# Altium schematic sheet [6] - A2 Full Schematic.SchDoc
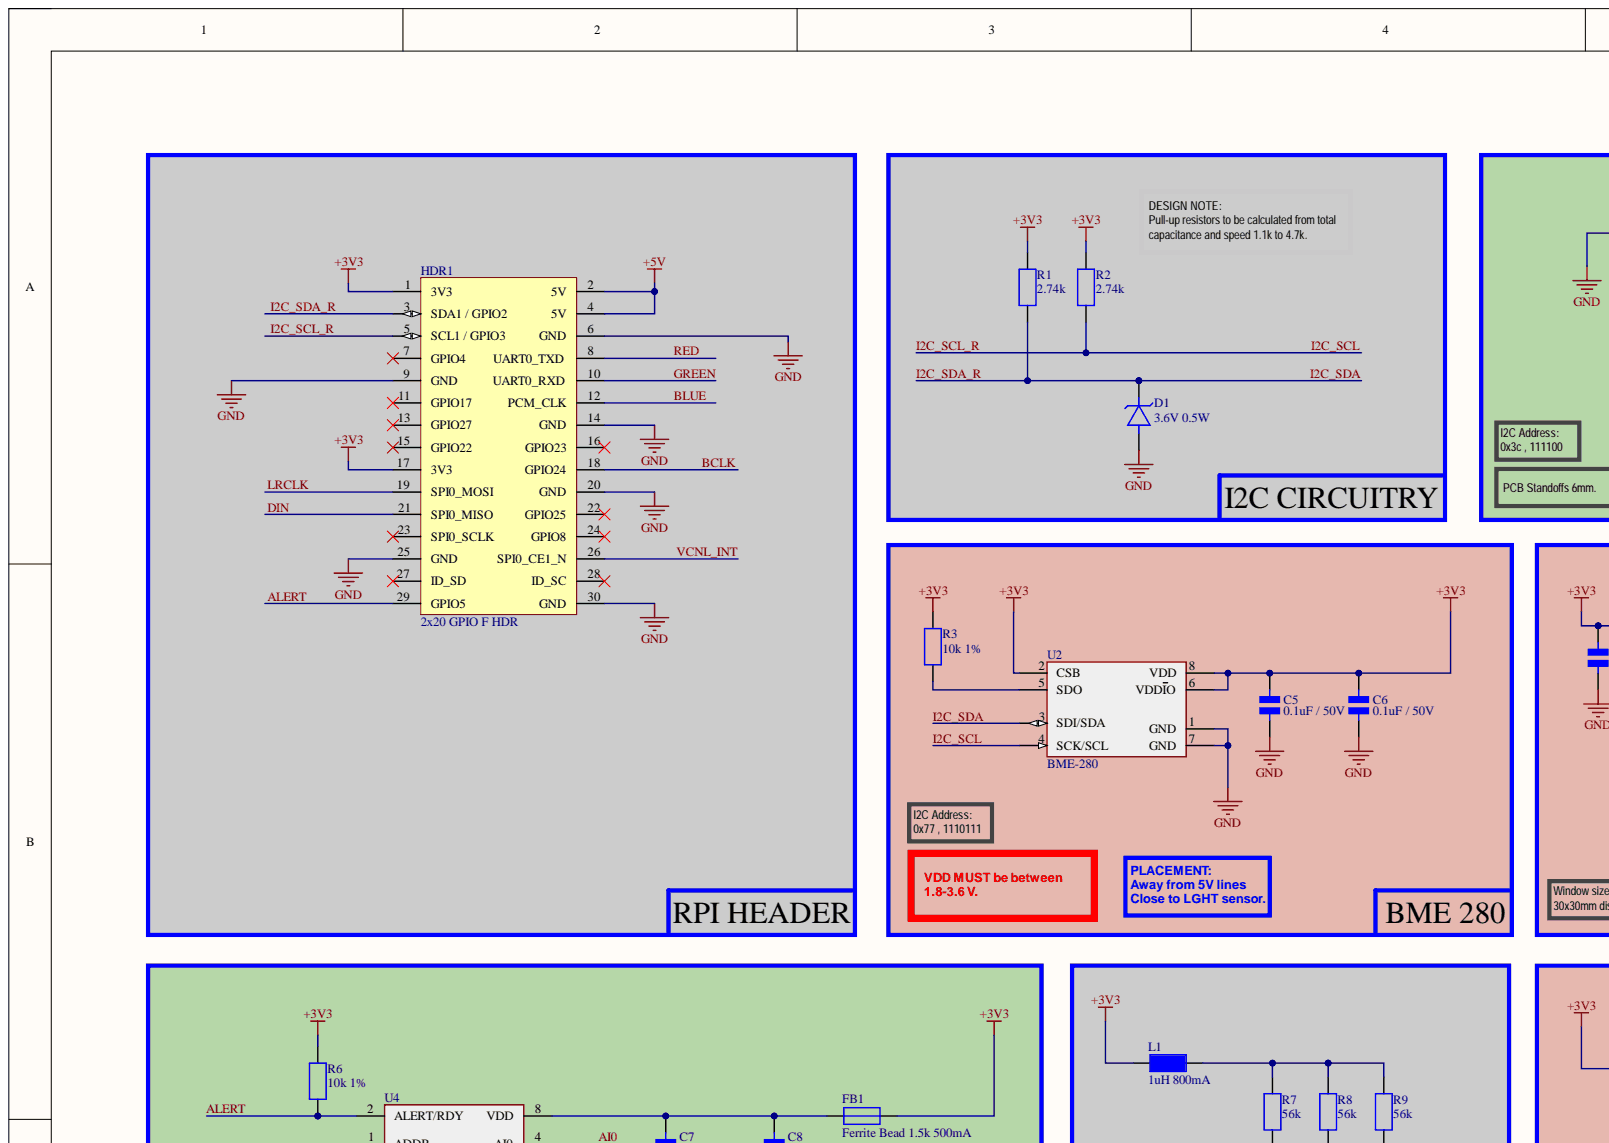
[diagram p0: [6] - A2 Full Schematic.SchDoc, top left]
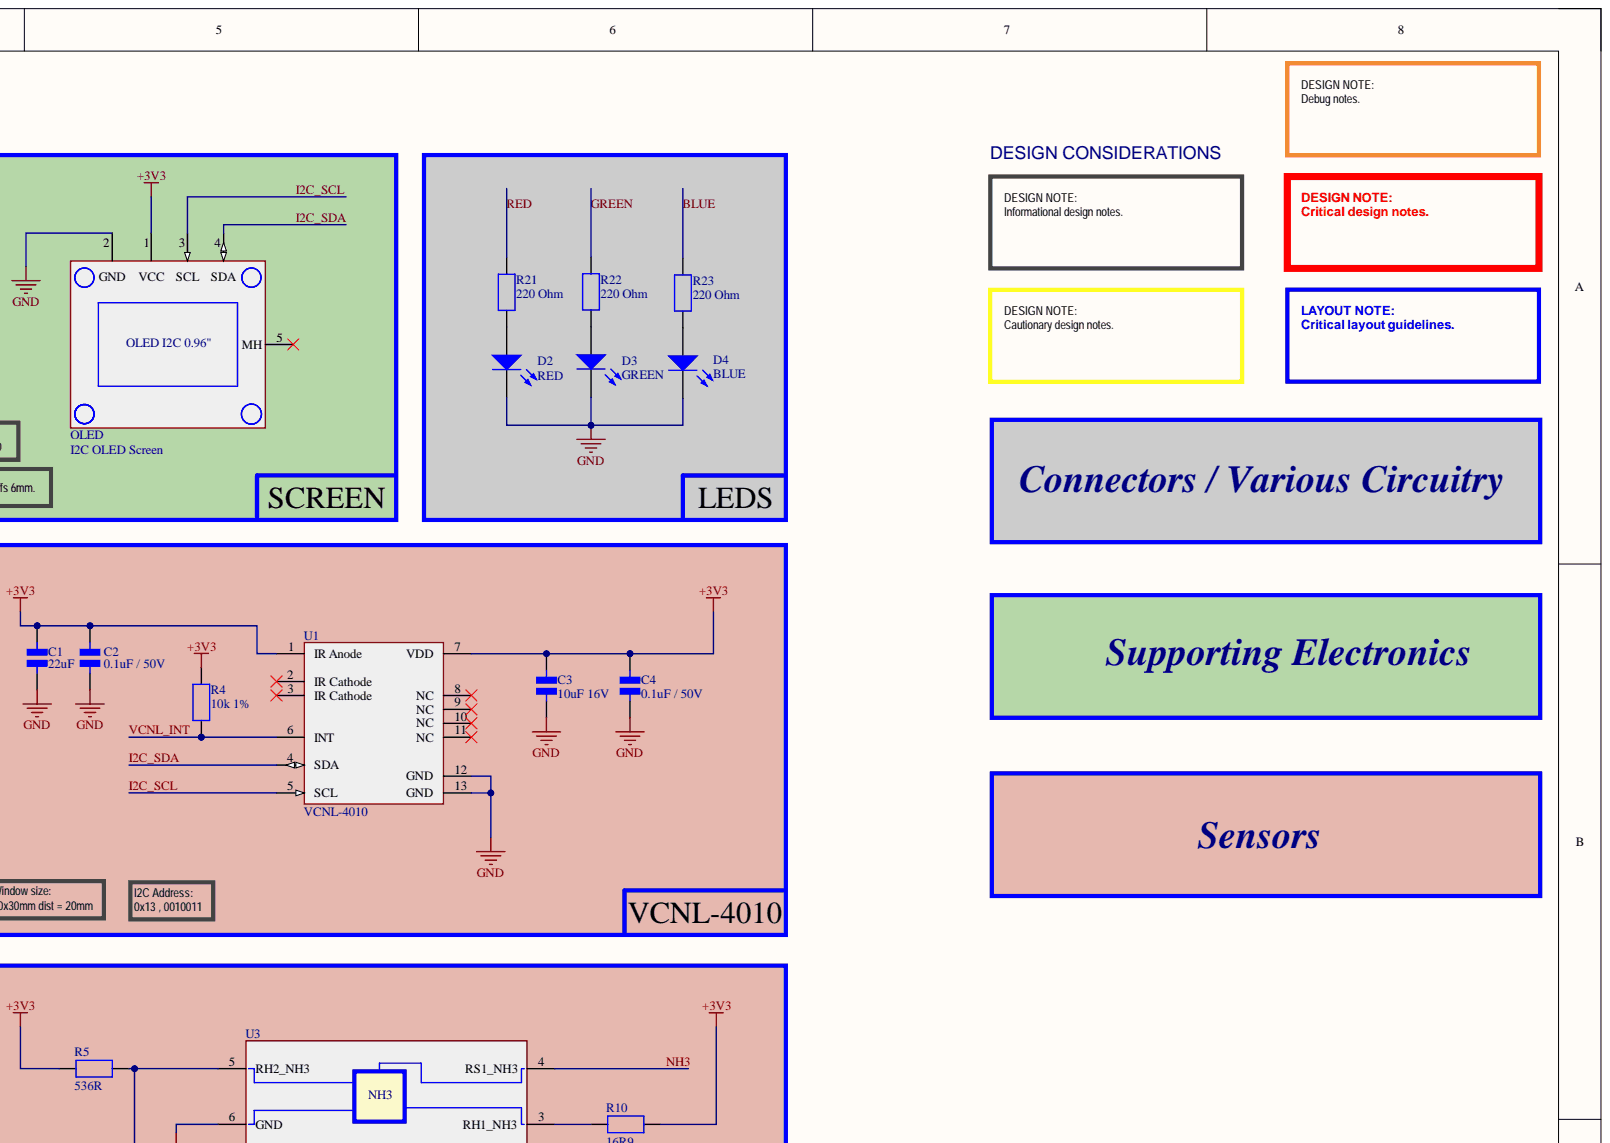
[diagram p1: [6] - A2 Full Schematic.SchDoc, top right]
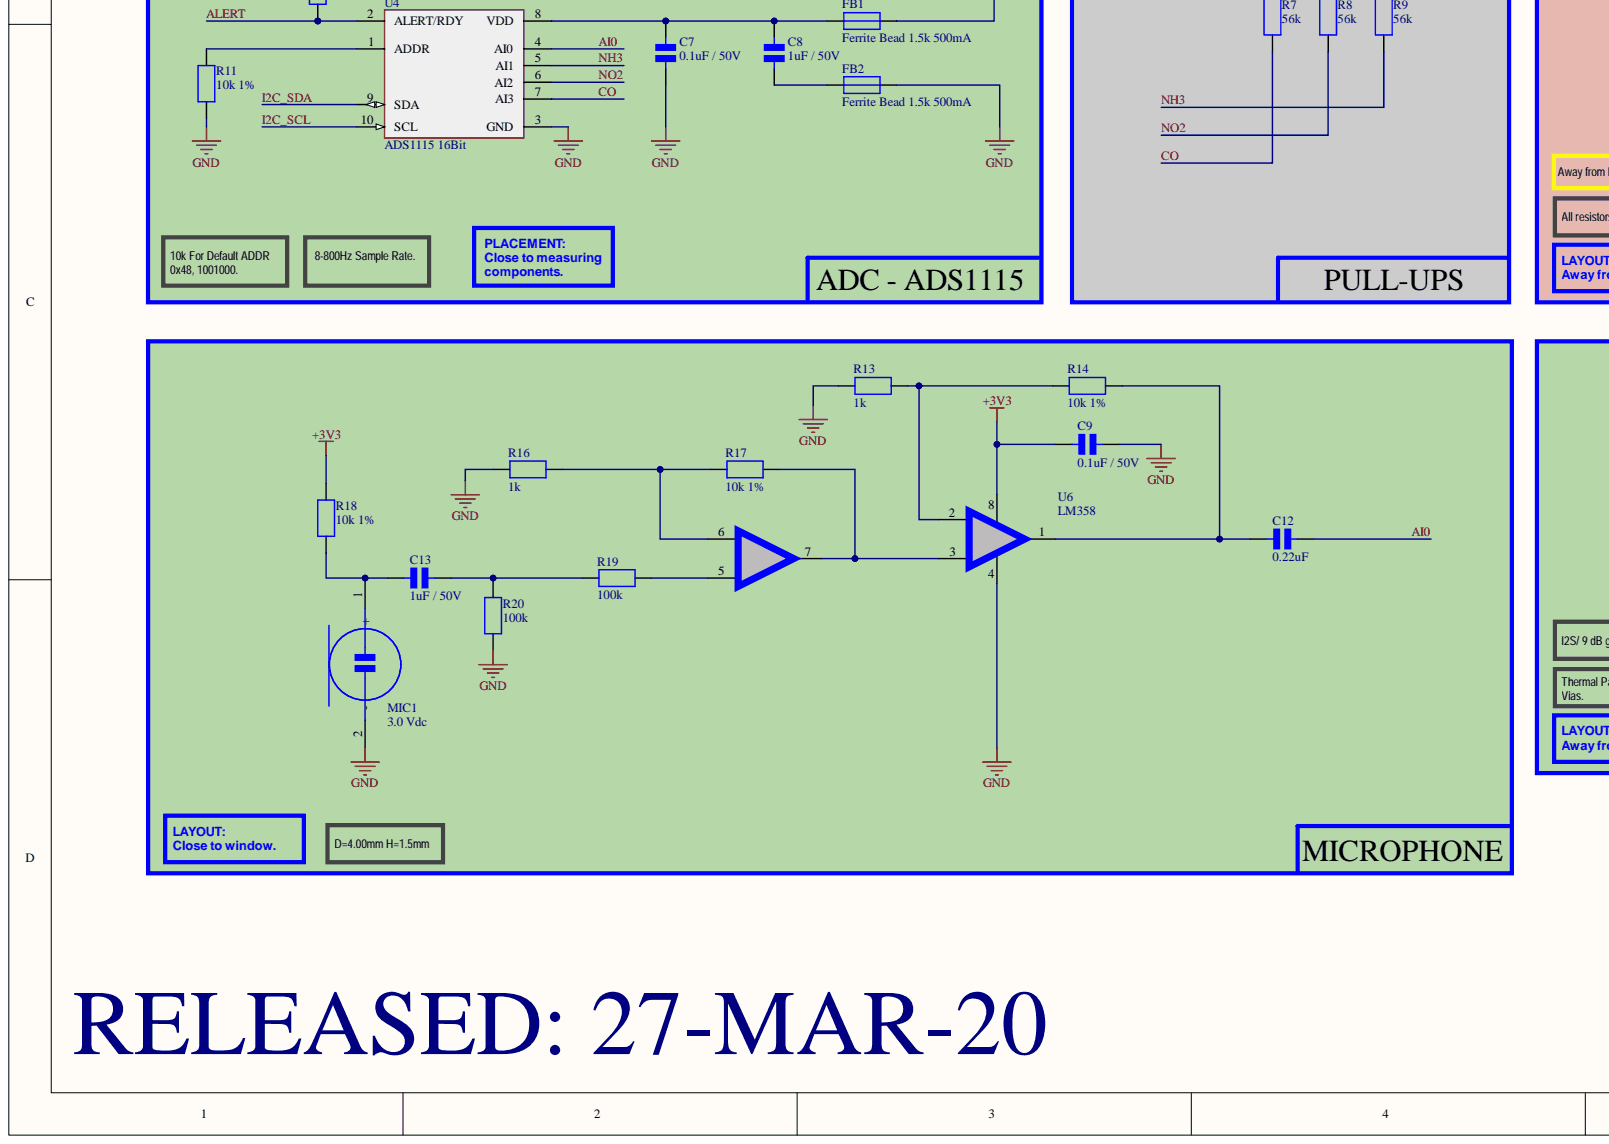
[diagram p2: [6] - A2 Full Schematic.SchDoc, bottom left]
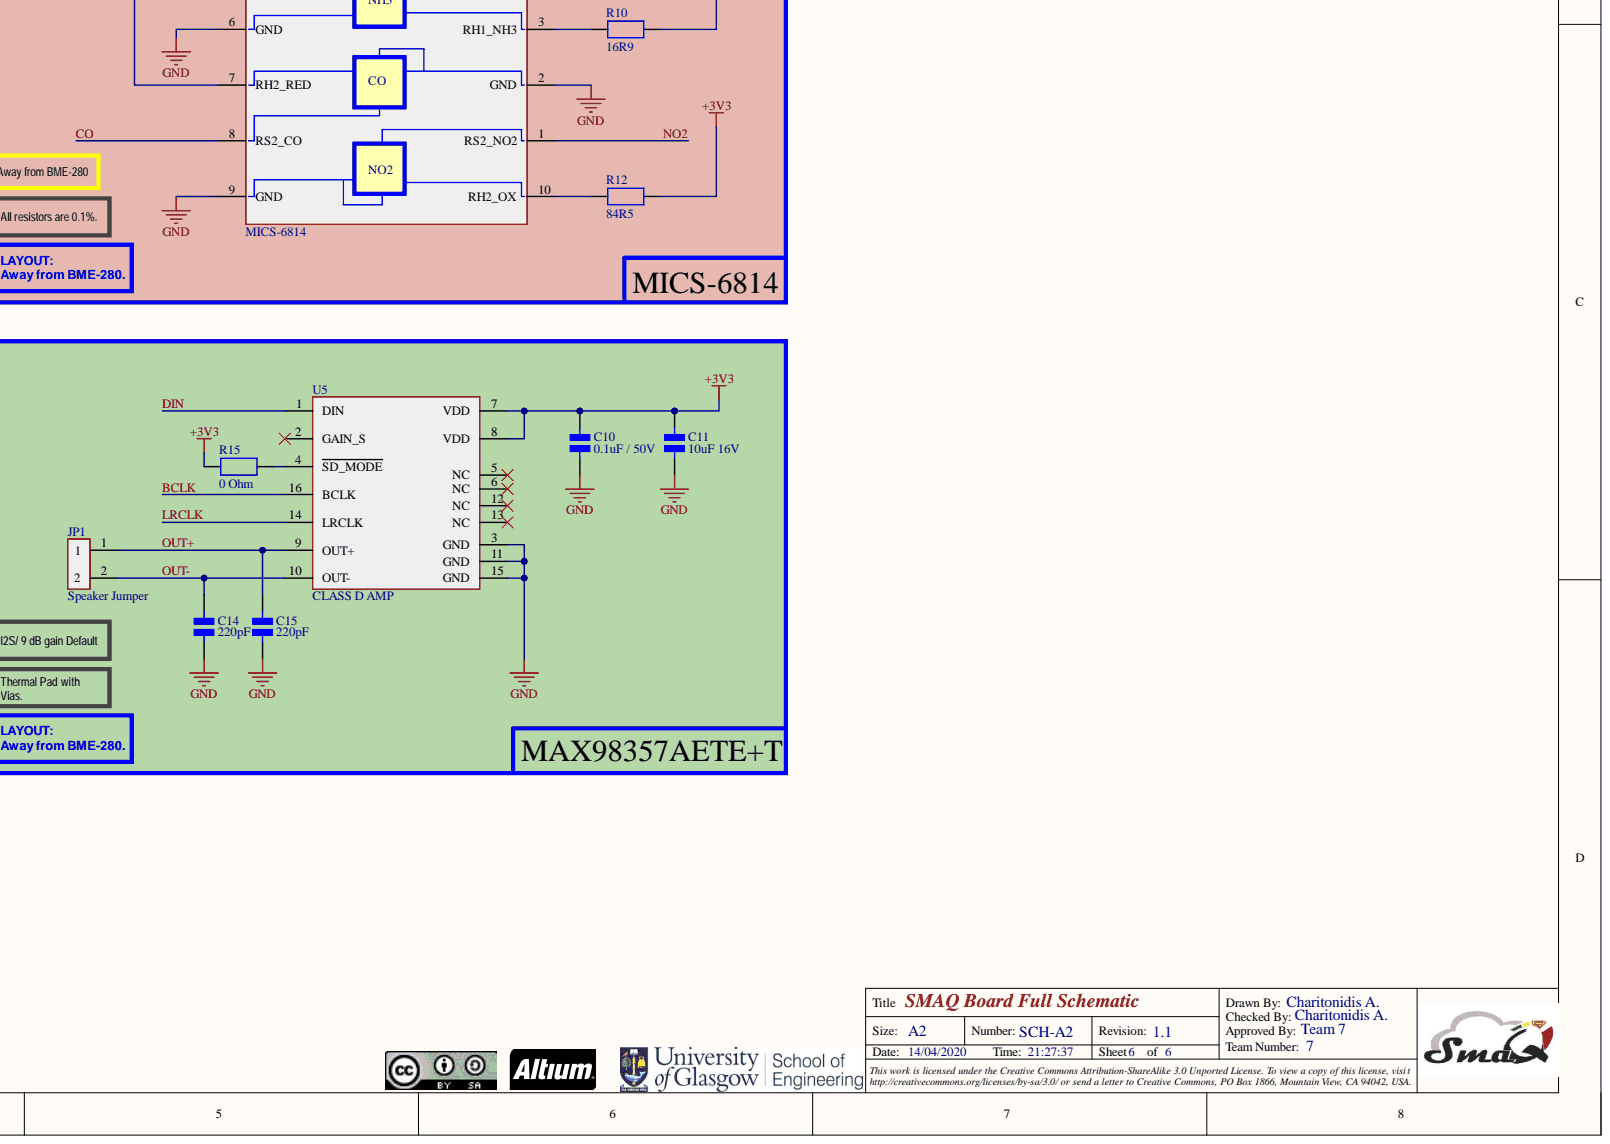
[diagram p3: [6] - A2 Full Schematic.SchDoc, bottom right]

## Parts
C1  22uF  [C0805]
C2  0.1uF / 50V  Voltage Rating: 50V  [C0805]
C3  10uF 16V  [C0805]
C4  0.1uF / 50V  Voltage Rating: 50V  [C0805]
C5  0.1uF / 50V  [C0805]
C6  0.1uF / 50V  Voltage Rating: 50V  [C0805]
C7  0.1uF / 50V  Voltage Rating: 50V  [C0805]
C8  1uF / 50V  Voltage: 50V  [C0805]
C9  0.1uF / 50V  Voltage Rating: 50V  [C0805]
C10  0.1uF / 50V  [C0805]
C11  10uF 16V  [C0805]
C12  0.22uF  [C0805]
C13  1uF / 50V  Voltage: 50V  [C0805]
C14  220pF  [C0805]
C15  220pF  [C0805]
D1  3.6V 0.5W  Zener Voltage: 3.6V  [SOD-123]
D2  RED  [LED-1]
D3  GREEN  [LED-1]
D4  BLUE  [LED-1]
FB1  Ferrite Bead 1.5k 500mA  Core Material: Ferrite  Current Rating: 500mA  [C0805]
FB2  Ferrite Bead 1.5k 500mA  Core Material: Ferrite  Current Rating: 500mA  [C0805]
HDR1  2x20 GPIO F HDR  [2x20_Pi_Header]
JP1  Speaker Jumper  [Speaker Jumper]
L1  1uH 800mA  [C0805]
MIC1  3.0 Vdc  [Speaker Jumper]
OLED  I2C OLED Screen  [OLED_I2C_0.96"]  (no BOM)
R1  2.74k  [R0805 (2012M)]
R2  2.74k  [R0805 (2012M)]
R3  10k 1%  Tolerance: 1%  [R0805 (2012M)]
R4  10k 1%  Tolerance: 1%  [R0805 (2012M)]
R5  536R  [R0805 (2012M)]
R6  10k 1%  Tolerance: 1%  [R0805 (2012M)]
R7  56k  [R0805 (2012M)]
R8  56k  [R0805 (2012M)]
R9  56k  [R0805 (2012M)]
R10  16R9  [R0805 (2012M)]
R11  10k 1%  Tolerance: 1%  [R0805 (2012M)]
R12  84R5  [R0805 (2012M)]
R13  1k  [R0805 (2012M)]
R14  10k 1%  Tolerance: 1%  [R0805 (2012M)]
R15  0 Ohm  [R0805 (2012M)]
R16  1k  [R0805 (2012M)]
R17  10k 1%  Tolerance: 1%  [R0805 (2012M)]
R18  10k 1%  Tolerance: 1%  [R0805 (2012M)]
R19  100k  [R0805 (2012M)]
R20  100k  [R0805 (2012M)]
R21  220 Ohm  [R0805 (2012M)]
R22  220 Ohm  [R0805 (2012M)]
R23  220 Ohm  [R0805 (2012M)]
U1  VCNL-4010  [VCNL-4010]
U2  BME-280  [LGA_8P_0.65MM]
U3  MICS-6814  [MICS-10]
U4  ADS1115 16Bit  [VSSOP-10]
U5  CLASS D AMP  [16LTHINQFN]
U6  LM358  [SOIC-8]

## Nets
+3V3: C1.2 C2.2 C3.2 C4.1 C5.2 C6.2 C9.1 C10.2 C11.2 FB1.2 HDR1.1(3V3) HDR1.17(3V3) L1.1 OLED.1 R1.2 R2.2 R3.2 R4.2 R5.1 R6.2 R10.2 R12.2 R15.2 R18.2 U1.1(IR_Anode) U1.7(VDD) U2.2(CSB) U2.6(VDDIO) U2.8(VDD) U5.7(VDD) U5.8(VDD) U6.8
+5V: HDR1.2(5V) HDR1.4(5V)
GND: C1.1 C2.1 C3.1 C4.2 C5.1 C6.1 C7.1 C9.2 C10.1 C11.1 C14.1 C15.1 D1.1 D2.2 D3.2 D4.2 FB2.2 HDR1.6(GND) HDR1.9(GND) HDR1.14(GND) HDR1.20(GND) HDR1.25(GND) HDR1.30(GND) MIC1.2 OLED.2 R11.1 R13.1 R16.1 R20.1 U1.12(GND) U1.13(GND) U2.1(GND) U2.7(GND) U3.2(GND) U3.6(GND) U3.9(GND) U4.3(GND) U5.3(GND) U5.11(GND) U5.15(GND) U6.4
AI0: C12.2 U4.4(AI0)
ALERT: HDR1.29(GPIO5) R6.1 U4.2(ALERT/RDY)
BCLK: HDR1.18(GPIO24) U5.16(BCLK)
BLUE: HDR1.12(PCM_CLK) R23.2
CO: R7.1 U3.8(RS2_CO) U4.7(AI3)
DIN: HDR1.21(SPI0_MISO) U5.1(DIN)
GREEN: HDR1.10(UART0_RXD) R22.2
I2C_SCL: HDR1.5(SCL1_/_GPIO3) OLED.3 R2.1 U1.5(SCL) U2.4(SCK/SCL) U4.10(SCL)
I2C_SDA: D1.2 HDR1.3(SDA1_/_GPIO2) OLED.4 R1.1 U1.4(SDA) U2.3(SDI/SDA) U4.9(SDA)
LRCLK: HDR1.19(SPI0_MOSI) U5.14(LRCLK)
NH3: R9.1 U3.4(RS1_NH3) U4.5(AI1)
NO2: R8.1 U3.1(RS2_NO2) U4.6(AI2)
OUT+: C15.2 JP1.1 U5.9(OUT+)
OUT-: C14.2 JP1.2 U5.10(OUT-)
RED: HDR1.8(UART0_TXD) R21.2
VCNL_INT: HDR1.26(SPI0_CE1_N) R4.1 U1.6(INT)
NetC7_2: C7.2 C8.2 FB1.1 U4.8(VDD)
NetC8_1: C8.1 FB2.1
NetC12_1: C12.1 R14.2 U6.1
NetC13_1: C13.1 MIC1.1 R18.1
NetC13_2: C13.2 R19.2 R20.2
NetD2_1: D2.1 R21.1
NetD3_1: D3.1 R22.1
NetD4_1: D4.1 R23.1
NetL1_2: L1.2 R7.2 R8.2 R9.2
NetR3_1: R3.1 U2.5(SDO)
NetR5_2: R5.2 U3.5(RH2_NH3) U3.7(RH2_RED)
NetR10_1: R10.1 U3.3(RH1_NH3)
NetR11_2: R11.2 U4.1(ADDR)
NetR12_1: R12.1 U3.10(RH2_OX)
NetR13_2: R13.2 R14.1 U6.2
NetR15_1: R15.1 U5.4(SD_MODE)
NetR16_2: R16.2 R17.2 U6.6
NetR17_1: R17.1 U6.3 U6.7
NetR19_1: R19.1 U6.5

Not connected: HDR1 7(GPIO4) 11(GPIO17) 13(GPIO27) 15(GPIO22) 16(GPIO23) 22(GPIO25) 23(SPI0_SCLK) 24(GPIO8) 27(ID_SD) 28(ID_SC); OLED 5(MH); U1 2(IR_Cathode) 3(IR_Cathode) 8(NC) 9(NC) 10(NC) 11(NC); U5 2(GAIN_S) 5(NC) 6(NC) 12(NC) 13(NC)
